# Altium schematic sheet ch347.SchDoc
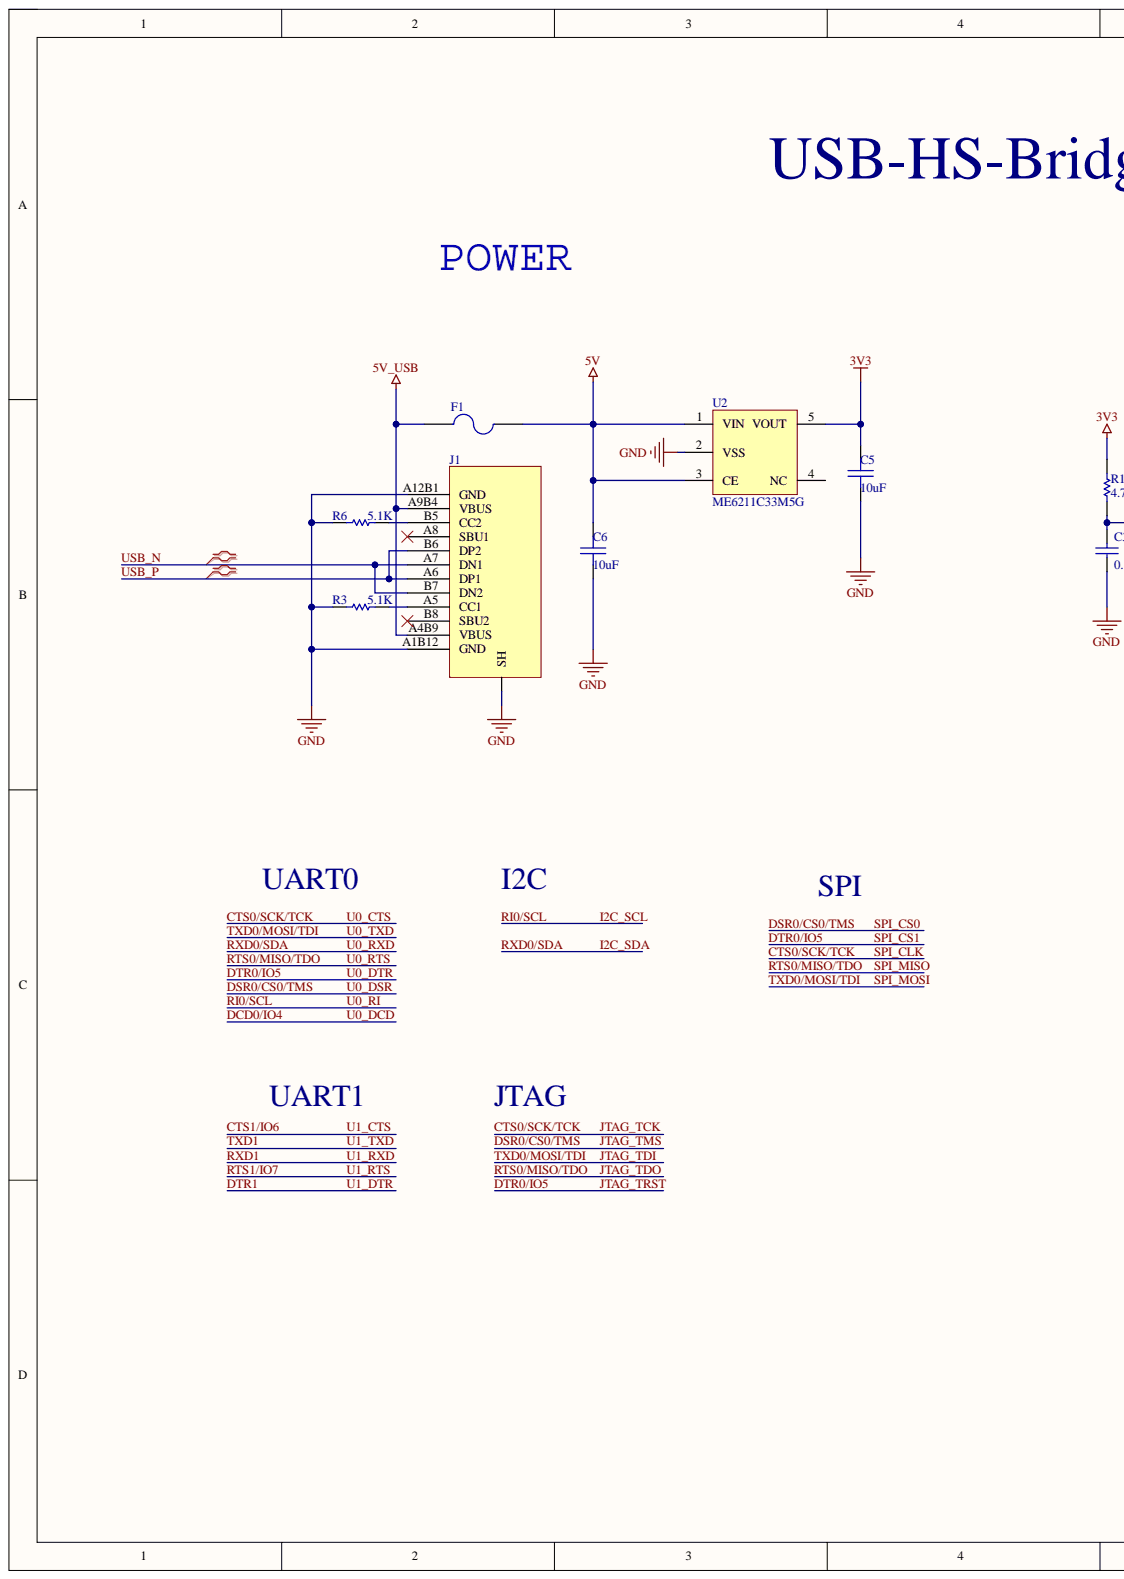
[diagram p0: ch347.SchDoc, left]
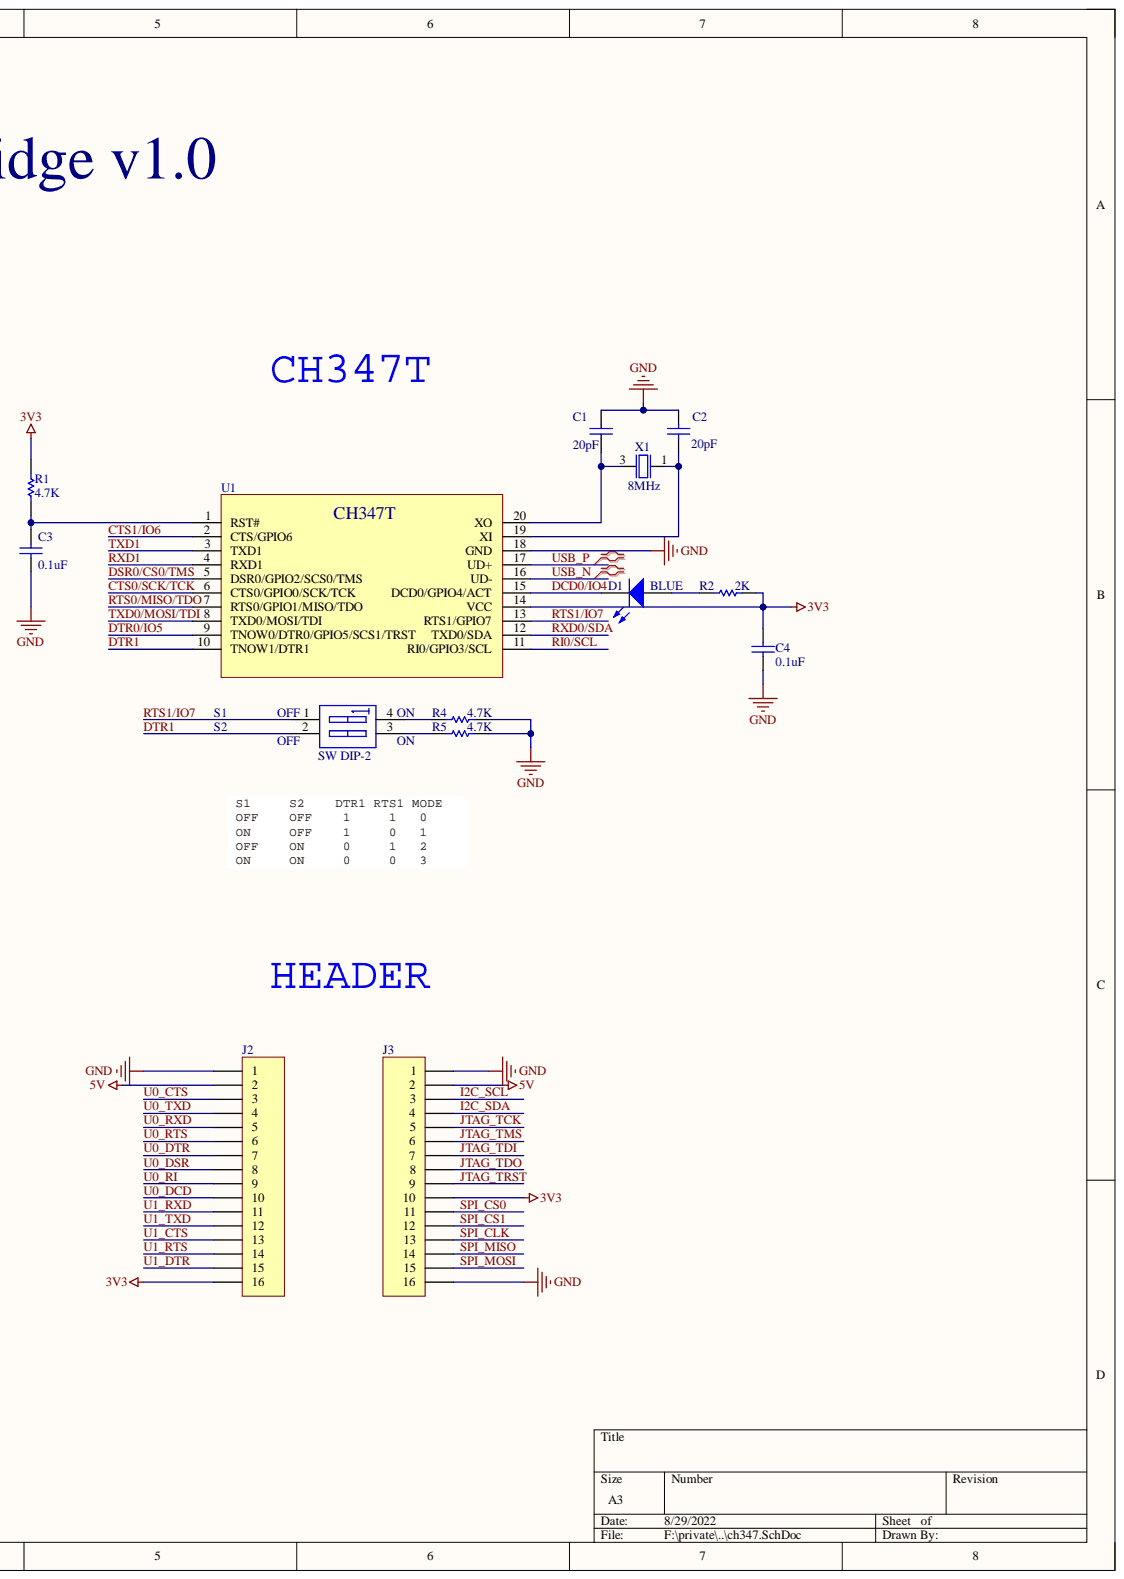
[diagram p1: ch347.SchDoc, right]

## Parts
C1  value 20pF  [C 0402_M_new]  (hidden comment: C0603)
C2  value 20pF  [C 0402_M_new]  (hidden comment: C0603)
C3  0.1uF  [C 0402_M_new]
C4  0.1uF  [C 0402_M_new]
C5  10uF  [0603_C]
C6  10uF  [0603_C]
D1  BLUE  [0603_LED_B]
F1  Fuse 2  [FU 0805]  (value not drawn)
J1  Type_C_16P  [Type_C_16P]  (value not drawn)
J2  Header 16  [HEADER_16x1]  (value not drawn)
J3  Header 16  [HEADER_16x1]  (value not drawn)
R1  4.7K  [R 0402_M_new]
R2  2K  [R 0402_M_new]
R3  value 5.1K  [R 0402_M_new]  (hidden comment: R0603)
R4  4.7K  [R 0402_M_new]
R5  4.7K  [R 0402_M_new]
R6  value 5.1K  [R 0402_M_new]  (hidden comment: R0603)
S1  SW DIP-2  [CSW1.27 SMD-2P]
U1  CH347T  [CH347T]
U2  ME6211C33M5G  [SOT23-5]
X1  8MHz  [BT-XTAL_3225]

## Nets
3V3: C4.1 C5.1 J2.16 J3.10 R1.2 R2.1 U1.14(VCC) U2.5(VOUT)
5V: C6.1 F1.2 J2.2 J3.2 U2.1(VIN) U2.3(CE)
5V_USB: F1.1 J1.A4B9(VBUS) J1.A9B4(VBUS)
GND: C1.2 C2.2 C3.1 C4.2 C5.2 C6.2 J1.0(SH) J1.A1B12(GND) J1.A12B1(GND) J2.1 J3.1 J3.16 R3.1 R4.1 R5.1 R6.1 U1.18(GND) U2.2(VSS) X1.2 X1.4
CTS0/SCK/TCK: J2.3 J3.5 J3.13 U1.6(CTS0/GPIO0/SCK/TCK)
CTS1/IO6: J2.13 U1.2(CTS/GPIO6)
DCD0/IO4: D1.2 J2.10 U1.15(DCD0/GPIO4/ACT)
DSR0/CS0/TMS: J2.8 J3.6 J3.11 U1.5(DSR0/GPIO2/SCS0/TMS)
DTR0/IO5: J2.7 J3.9 J3.12 U1.9(TNOW0/DTR0/GPIO5/SCS1/TRST)
DTR1: J2.15 S1.2 U1.10(TNOW1/DTR1)
I2C_SCL: J2.9 J3.3 U1.11(RI0/GPIO3/SCL)
I2C_SDA: J2.5 J3.4 U1.12(TXD0/SDA)
JTAG_TDI: J2.4 J3.7 J3.15 U1.8(TXD0/MOSI/TDI)
JTAG_TDO: J2.6 J3.8 J3.14 U1.7(RTS0/GPIO1/MISO/TDO)
RTS1/IO7: J2.14 S1.1 U1.13(RTS1/GPIO7)
RXD1: J2.11 U1.4(RXD1)
TXD1: J2.12 U1.3(TXD1)
USB_N: J1.A7(DN1) J1.B7(DN2) U1.16(UD-)
USB_P: J1.A6(DP1) J1.B6(DP2) U1.17(UD+)
NetC1_1: C1.1 U1.20(XO) X1.3
NetC2_1: C2.1 U1.19(XI) X1.1
NetC3_2: C3.2 R1.1 U1.1(RST#)
NetD1_1: D1.1 R2.2
NetJ1_A5: J1.A5(CC1) R3.2
NetJ1_B5: J1.B5(CC2) R6.2
NetR4_2: R4.2 S1.4
NetR5_2: R5.2 S1.3

Not connected: J1 A8(SBU1) B8(SBU2); U2 4(NC)

## Library data of the parts
C1-C4 = C0603: Capacitor; Component Kind: Standard; LatestRevisionDate: 17-Jul-2002; LatestRevisionNote: Re-released for DXP Platform.; Library Name: MYLIB.IntLib; PackageDescription: Radial Cap, Thru-Hole; 2 Leads; 0.3 in Pin Spacing; PackageReference: RAD-0.3; Published: 8-Jun-2000; Signal Integrity: Cap; Simulation: CAP; Publisher: Altium Limited
  C3 C4: Value: 0.1uF
C5 C6 = SMT_C_0805: Surface mount capacitor 0805; Component Kind: Standard; Library Name: Vowstar_Basic_Device.IntLib
D1 = D0603: Typical RED, GREEN, YELLOW, AMBER GaAs LED; Component Kind: Standard; LatestRevisionDate: 17-Jul-2002; LatestRevisionNote: Re-released for DXP Platform.; Library Name: MYLIB.IntLib; PackageDescription: SM LED; 2 Flat Leads; PackageReference: 3.2X1.6X1.1; PackageVersion: Feb-2002; Published: 8-Jun-2000; Simulation: LED2; Publisher: Altium Limited
F1 = Fuse 2: Fuse; Component Kind: Standard; LatestRevisionDate: 17-Jul-2002; LatestRevisionNote: Re-released for DXP Platform.; Library Name: Miscellaneous Devices.IntLib; PackageDescription: Thru-Hole; 2 Leads; PackageReference: PIN-W2/E2.8; Published: 8-Jun-2000; Simulation: FUSE; Publisher: Altium Limited
R1-R6 = R0603: Resistor; Component Kind: Standard; LatestRevisionDate: 17-Jul-2002; LatestRevisionNote: Re-released for DXP Platform.; Library Name: MYLIB.IntLib; PackageDescription: Axial Device, Thru-Hole; 2 Leads; 0.3 in Pin Spacing; PackageReference: AXIAL-0.3; Published: 8-Jun-2000; Signal Integrity: Res; Simulation: RESISTOR; Publisher: Altium Limited
  R1 R4 R5: Value: 4.7K
  R2: Value: 2K
S1 = SW DIP-2: DIP Switch, 2 Position, SPST; Component Kind: Standard; LatestRevisionDate: 17-Jul-2002; LatestRevisionNote: Re-released for DXP Platform.; Library Name: Miscellaneous Devices.IntLib; PackageReference: DIP-4; Published: 24-Mar-1999; Simulation: dpsw2; Publisher: Altium Limited
X1 = XTAL SMD 12MHz (3225): XTAL SMD 3225, 8MHz, 18pF, ±30ppm; 2nd source part number: 184-2056; Manufacturer: TXC Corporation; Manufacturer Part Number: 7M-12.000MAAJ-T; Artikkelnr Noca: 22019166; Artikkelnr Simpro: N.A.; Database Table Name: Crystal_Osc$; Note: XTAL SMD 12.000MHz; Value: 12Mhz; 2nd source Supplier: Farnell; Component Kind: Standard; Datasheet: http://www.txccrystal.com/images/pdf/7m.pdf; Footprint Doc: BT-XTAL_3225; LatestRevisionDate: 2010-08-31; Library Name: Nordic Discrete DB.DbLib; Part Number: XTAL SMD 12MHz (3225); Pin Count: 4; Published: 2010-08-31; Supplier: Digi-Key; Supplier part number: 887-1121-1-ND; Publisher: Nordic Semiconductor
J1 = Type_C_16P: Component Kind: Standard; Library Name: ICESugar.SCHLIB
J2 J3 = Header 16: Component Kind: Standard; Library Name: ch347.SCHLIB
U1 = CH347T: Component Kind: Standard; Library Name: ch347.SCHLIB
U2 = ME6211C33M5G: Component Kind: Standard
